# Altium schematic sheet Power.SchDoc
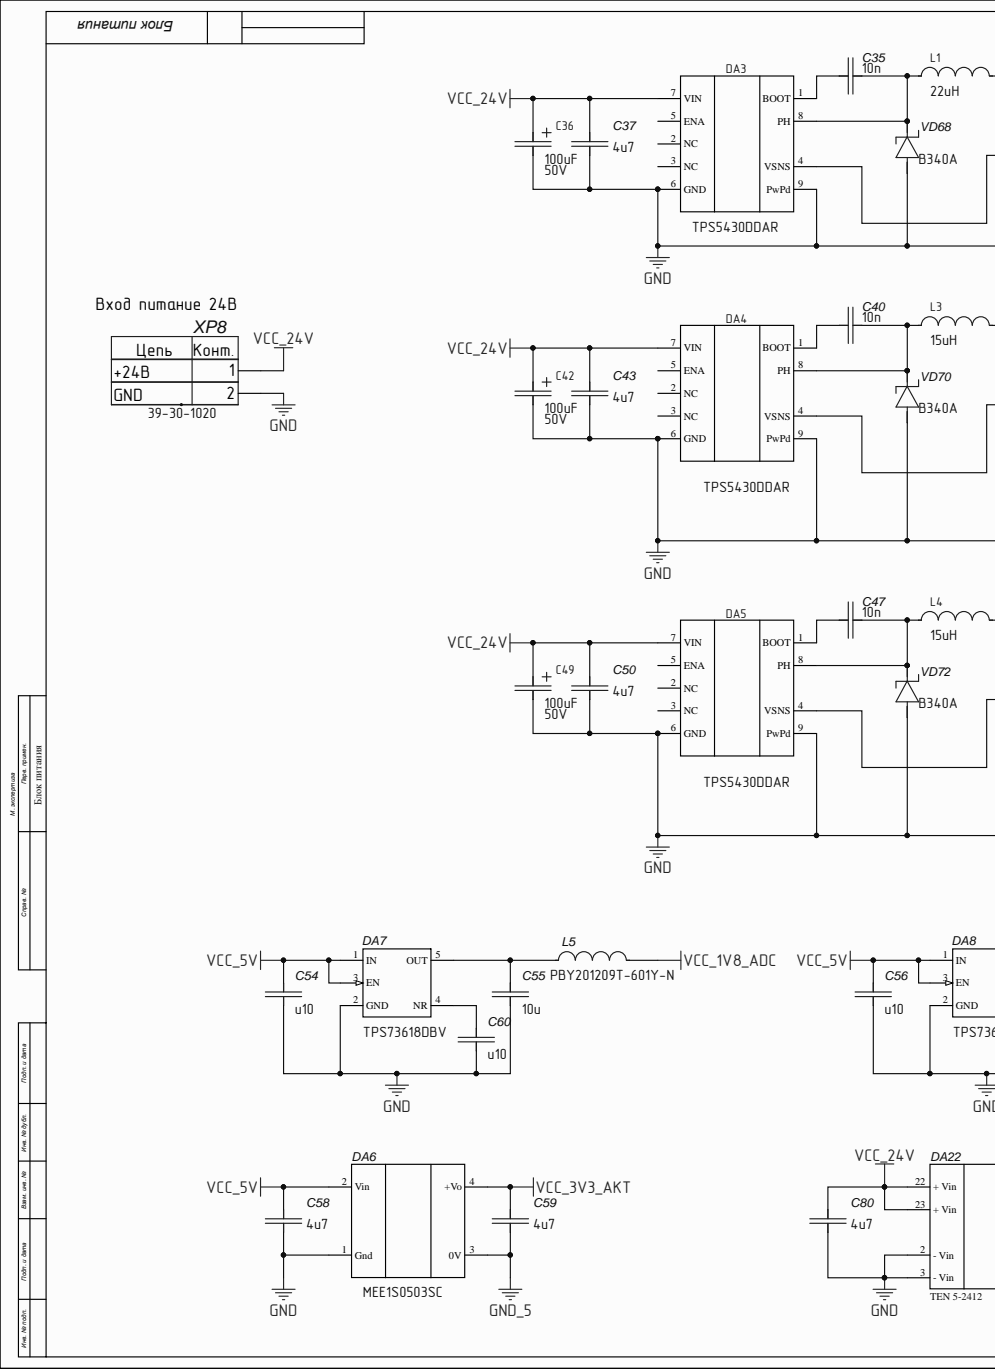
[diagram p0: Power.SchDoc, left]
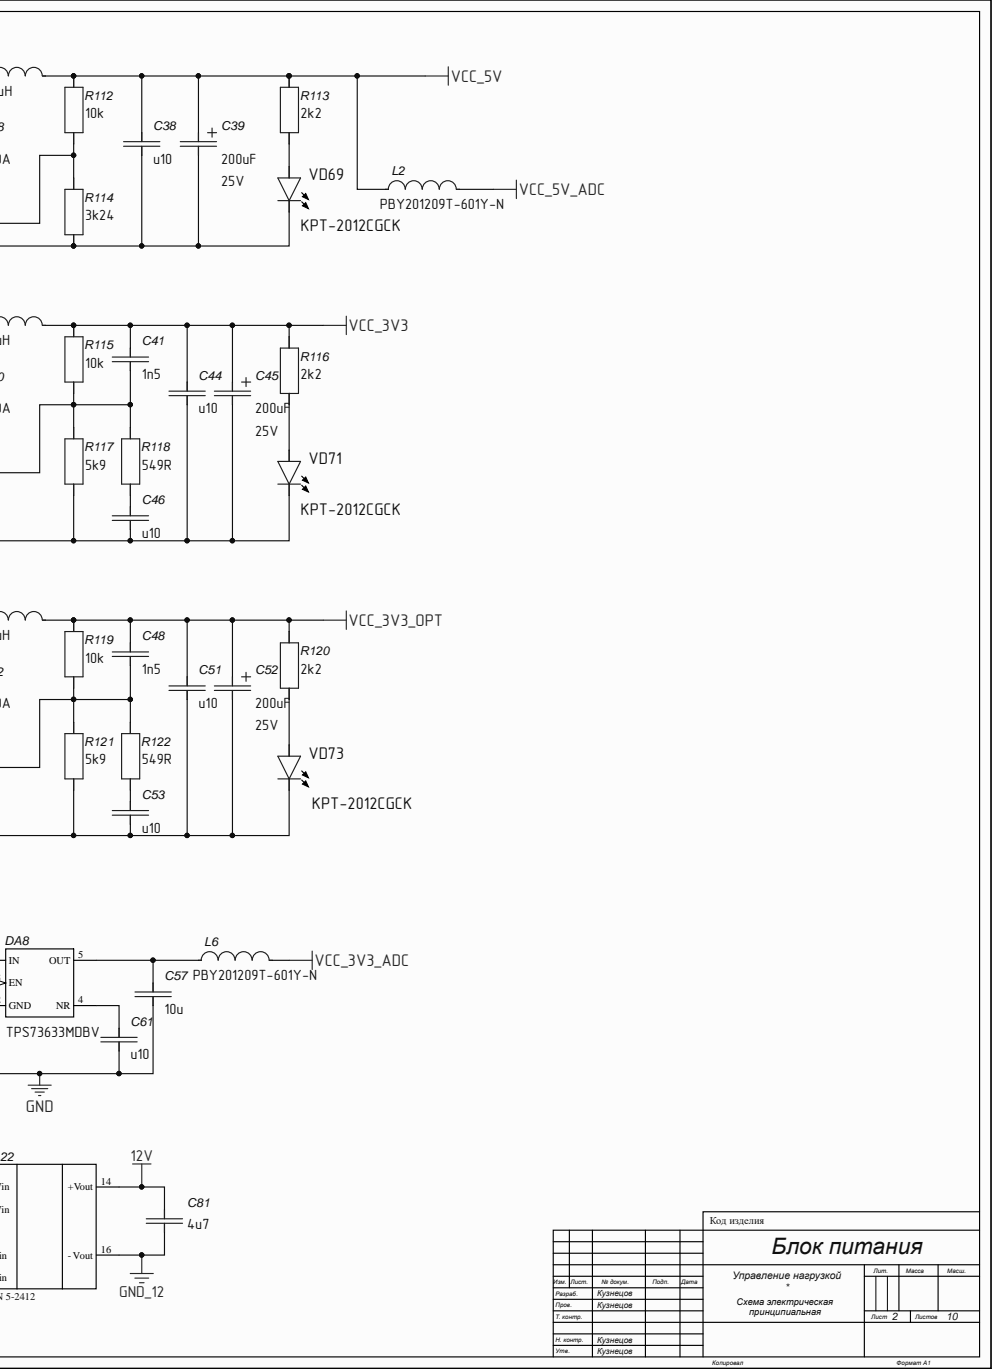
[diagram p1: Power.SchDoc, right]

## Parts
C35  10n
C36  100uF  Power\Voltage: 50V  [ELCAP_G]
C37  4u7  Power\Voltage: 50V
C38  u10
C39  200uF  Power\Voltage: 25V  [ELCAP_F]
C40  10n
C41  1n5
C42  100uF  Power\Voltage: 50V  [ELCAP_G]
C43  4u7  Power\Voltage: 50V
C44  u10
C45  200uF  [ELCAP_F]
C46  u10
C47  10n
C48  1n5
C49  100uF  Power\Voltage: 50V  [ELCAP_G]
C50  4u7  Power\Voltage: 50V
C51  u10
C52  200uF  [ELCAP_F]
C53  u10
C54  u10
C55  10u
C56  u10
C57  10u
C58  4u7
C59  4u7
C60  u10
C61  u10
C80  4u7
C81  4u7
DA3  TPS5430DDAR  [HSOP-8]
DA4  TPS5430DDAR  [HSOP-8]
DA5  TPS5430DDAR  [HSOP-8]
DA6  MEE1S0503SC  [SIP4]
DA7  TPS73618DBV  [SOT-23-5]
DA8  TPS73633MDBV  [SOT-23-5]
DA22  TEN 5-2412  [DIP-24]
L1  22uH  [SRR-1206]
L2  PBY201209T-601Y-N  [0805_bead]
L3  15uH  [SRR-1206]
L4  15uH  [SRR-1206]
L5  PBY201209T-601Y-N  [0805_bead]
L6  PBY201209T-601Y-N  [0805_bead]
R112  10k  [0805]
R113  2k2  [0805]
R114  3k24  [0805]
R115  10k  [0805]
R116  2k2  [0805]
R117  5k9  [0805]
R118  549R  [0805]
R119  10k  [0805]
R120  2k2  [0805]
R121  5k9  [0805]
R122  549R  [0805]
VD68  B340A  [DO-214AC(SMA)]
VD69  KPT-2012CGCK  [0805_LED_GREEN]
VD70  B340A  [DO-214AC(SMA)]
VD71  KPT-2012CGCK  [0805_LED_GREEN]
VD72  B340A  [DO-214AC(SMA)]
VD73  KPT-2012CGCK  [0805_LED_GREEN]
XP8  39-30-1020  [MINI-FIT JR -2]

## Nets
12V: C81.2 DA22.14(+Vout)
GND: C36.1 C37.1 C38.2 C39.1 C42.1 C43.1 C44.2 C45.1 C46.2 C49.1 C50.1 C51.2 C52.1 C53.2 C54.2 C55.2 C56.2 C57.2 C58.1 C60.2 C61.2 C80.1 DA3.6(GND) DA3.9(PwPd) DA4.6(GND) DA4.9(PwPd) DA5.6(GND) DA5.9(PwPd) DA6.1(Gnd) DA7.2(GND) DA8.2(GND) DA22.2(-_Vin) DA22.3(-_Vin) R114.1 R117.1 R121.1 VD68.2 VD69.2 VD70.2 VD71.2 VD72.2 VD73.2 XP8.2
GND_5: C59.1 DA6.3(0V)
GND_12: C81.1 DA22.16(-_Vout)
VCC_1V8_ADC: L5.2
VCC_3V3: C41.1 C44.1 C45.2 L3.2 R115.2 R116.2
VCC_3V3_ADC: L6.2
VCC_3V3_AKT: C59.2 DA6.4(+Vo)
VCC_3V3_OPT: C48.1 C51.1 C52.2 L4.2 R119.2 R120.2
VCC_5V: C38.1 C39.2 C54.1 C56.1 C58.2 DA6.2(Vin) DA7.1(IN) DA7.3(EN) DA8.1(IN) DA8.3(EN) L1.2 L2.1 R112.2 R113.2
VCC_5V_ADC: L2.2
VCC_24V: C36.2 C37.2 C42.2 C43.2 C49.2 C50.2 C80.2 DA3.7(VIN) DA4.7(VIN) DA5.7(VIN) DA22.22(+_Vin) DA22.23(+_Vin) XP8.1
NetC35_1: C35.1 DA3.8(PH) L1.1 VD68.1
NetC35_2: C35.2 DA3.1(BOOT)
NetC40_1: C40.1 DA4.8(PH) L3.1 VD70.1
NetC40_2: C40.2 DA4.1(BOOT)
NetC41_2: C41.2 DA4.4(VSNS) R115.1 R117.2 R118.2
NetC46_1: C46.1 R118.1
NetC47_1: C47.1 DA5.8(PH) L4.1 VD72.1
NetC47_2: C47.2 DA5.1(BOOT)
NetC48_2: C48.2 DA5.4(VSNS) R119.1 R121.2 R122.2
NetC53_1: C53.1 R122.1
NetC55_1: C55.1 DA7.5(OUT) L5.1
NetC57_1: C57.1 DA8.5(OUT) L6.1
NetC60_1: C60.1 DA7.4(NR)
NetC61_1: C61.1 DA8.4(NR)
NetDA3_4: DA3.4(VSNS) R112.1 R114.2
NetR113_1: R113.1 VD69.1
NetR116_1: R116.1 VD71.1
NetR120_1: R120.1 VD73.1

Not connected: DA3 2(NC) 3(NC) 5(ENA); DA4 2(NC) 3(NC) 5(ENA); DA5 2(NC) 3(NC) 5(ENA)

## Library data of the parts
C35 C38 C40 C41 C44 C46-C48 C51 C53 = (no symbol name): Capacitor; Power\Voltage: 25V; Tolerance: 10%; Корпус: 0805; Component Kind: Standard; ComponentLink1Description: Параметры; ComponentLink2Description: Сайт производителя; Author: Eleman; Library Name: eleman_capacitor.schlib
  C35 C40 C47: Value: 10n
  C38 C44 C46 C51 C53: Value: u10
  C41 C48: Value: 1n5
C36 C42 C49 = ELCAP G: Конденсатор электролитический алюминий; Manufacturer: Panasonic Electronic; ManufacturerPartNumber: EEH-ZC1H101P; Rule: Undefined; Tolerance: 20%; Корпус: 1010; Component Kind: Standard; ComponentLink1Description: Параметры; ComponentLink2Description: Сайт производителя; Value: 100uF; Author: Eleman; ComponentLink1URL: d:\YandexDisk\Project_Altium\Lib_Eleman\STEP\Capacitor\; Library Name: eleman_capacitor.schlib
C37 C43 C50 = (no symbol name): Capacitor; Tolerance: 10%; Корпус: 0805; Component Kind: Standard; ComponentLink1Description: Параметры; ComponentLink2Description: Сайт производителя; Value: 4u7; Author: Eleman; Library Name: eleman_capacitor.schlib
C39 = ELCAP F: Конденсатор электролитический алюминий; Manufacturer: Panasonic Electronic; ManufacturerPartNumber: EEH-ZC1E221P; Rule: Undefined; Tolerance: 20%; Корпус: 0810; Component Kind: Standard; ComponentLink1Description: Параметры; ComponentLink2Description: Сайт производителя; Value: 200uF; Author: Eleman; ComponentLink1URL: d:\YandexDisk\Project_Altium\Lib_Eleman\STEP\Capacitor\; Library Name: eleman_capacitor.schlib
C45 C52 = ELCAP F: Конденсатор электролитический алюминий; Manufacturer: Panasonic Electronic; ManufacturerPartNumber: EEH-ZC1E221P; Power\Voltage: 25V; Rule: Undefined; Tolerance: 20%; Корпус: 0810; Component Kind: Standard; ComponentLink1Description: Параметры; ComponentLink2Description: Сайт производителя; Value: 200uF; Author: Eleman; ComponentLink1URL: d:\YandexDisk\Project_Altium\Lib_Eleman\STEP\Capacitor\; Library Name: eleman_capacitor.schlib
C54-C57 C60 C61 = (no symbol name): Capacitor; Power\Voltage: 6.3V; Tolerance: 10%; Корпус: 0805; Component Kind: Standard; ComponentLink1Description: Параметры; ComponentLink2Description: Сайт производителя; Author: Eleman; Library Name: eleman_capacitor.schlib
  C54 C56 C60 C61: Value: u10
  C55 C57: Value: 10u
C58 C59 C80 C81 = (no symbol name): Capacitor; Power\Voltage: 50V; Tolerance: 10%; Корпус: 0805; Component Kind: Standard; ComponentLink1Description: Параметры; ComponentLink2Description: Сайт производителя; Value: 4u7; Author: Eleman; Library Name: eleman_capacitor.schlib
DA3-DA5 = TPS5430DDAR: Источник питания; Component Kind: Standard; ComponentLink1Description: Параметры; ComponentLink2Description: Сайт производителя; ManufacturerPartNumber: TPS5430DDAR; Корпус: HSOP-8; Author: Eleman; Library Name: Eleman_Power.SchLib
DA6 = MEE1S0503SC: Источник питания; Корпус: 4-SIP; Component Kind: Standard; ComponentLink1Description: Параметры; ComponentLink2Description: Сайт производителя; ManufacturerPartNumber: MEE1S0503SC; Author: Eleman; Library Name: Eleman_Power.SchLib
DA7 = TPS73618DBV: Источник питания; Power\Voltage: 1V8; Component Kind: Standard; ComponentLink1Description: Параметры; ComponentLink2Description: Сайт производителя; ManufacturerPartNumber: TPS73618DBV; Author: Eleman; Library Name: Eleman_Power.SchLib
DA8 = TPS73633MDBV: Источник питания; Power\Voltage: 3V3; Component Kind: Standard; ComponentLink1Description: Параметры; ComponentLink2Description: Сайт производителя; ManufacturerPartNumber: TPS73633MDBV; Author: Eleman; Library Name: Eleman_Power.SchLib
DA22 = TEN 5-2412: Источник питания; Component Kind: Standard; ComponentLink1Description: Параметры; ComponentLink2Description: Сайт производителя; ManufacturerPartNumber: TEN 5-2412; Корпус: DIP-24; Author: Eleman; Library Name: Eleman_Power.SchLib
L1 L3 L4 = Inductor SRR1206: Индуктивность постоянная; Manufacturer: Bourns Inc.; ManufacturerPartNumber: SRR1206-220ML; Power\Voltage: 2.3A; Tolerance: 20%; Корпус: 1206; Component Kind: Standard; ComponentLink1Description: Параметры; ComponentLink2Description: Сайт производителя; Supplier 1: Digi-Key; Supplier Part Number 1: SRR1206-100MLTR-ND; Author: Eleman; Library Name: Eleman_Inductor.SchLib
  L1: Value: 22uH
  L3 L4: Value: 15uH
L2 L5 L6 = PBY201209T-601Y-N: Фильтр ферритовый; Manufacturer: Chilisin; Power\Voltage: 2A; Tolerance: 600 R @ 100Mhz; Component Kind: Standard; ComponentLink1Description: Параметры; ComponentLink2Description: Сайт производителя; ManufacturerPartNumber: PBY201209T-601Y-N; Корпус: SMD 0805; Author: Eleman; Library Name: Eleman_Inductor.SchLib
R112 R114 R115 R117-R119 R121 R122 = R0805: Импортный постоянный углеродистый резистор; Manufacturer: Vishay Dale; Power\Voltage: 0.125W; Tolerance: 1%; Component Kind: Standard; ComponentLink1Description: Параметры; ComponentLink2Description: Сайт производителя; ManufacturerPartNumber: R0805; Корпус: SMD 0805; Author: Eleman; Library Name: Eleman_Resistor.SchLib
  R112 R115 R119: Value: 10k
  R114: Value: 3k24
  R117 R121: Value: 5k9
  R118 R122: Value: 549R
R113 R116 R120 = R0805: Импортный постоянный углеродистый резистор; Manufacturer: Vishay Dale; Power\Voltage: 0.125W; Tolerance: 5%; Component Kind: Standard; ComponentLink1Description: Параметры; ComponentLink2Description: Сайт производителя; ManufacturerPartNumber: R0805; Value: 2k2; Корпус: SMD 0805; Author: Eleman; Library Name: Eleman_Resistor.SchLib
VD68 VD70 VD72 = DO-214AC SMA: Диод Шотки; Корпус: DO-214 SMA; Component Kind: Standard; ComponentLink1Description: Параметры; ComponentLink2Description: Сайт производителя; Value: B340A; Author: Eleman; ComponentLink1URL: d:\YandexDisk\Project_Altium\Lib_Eleman\; Library Name: Eleman_Diods.SchLib
VD69 VD71 VD73 = KPT-2012CGCK: Светодиод/Зеленый/Чип; Manufacturer: KINGBRIGHT; Корпус: 0805; Component Kind: Standard; ComponentLink1Description: Параметры; ComponentLink2Description: Сайт производителя; ManufacturerPartNumber: KPT-2012CGCK; Value: KPT-2012CGCK; Author: Eleman; ComponentLink1URL: d:\YandexDisk\Project_Altium\Lib_Eleman\; Library Name: Eleman_Diods.SchLib
XP8 = 39-30-1020: Разъем; Manufacturer: Molex; Rem: Mini-Fit Jr 2; Корпус: Mini Fit; Component Kind: Standard; ComponentLink1Description: Параметры; ComponentLink2Description: Сайт производителя; ManufacturerPartNumber: 39-30-1020; Author: Eleman; ComponentLink1URL: C:\Demo\Datasheet; Library Name: Eleman_Connector.SchLib
